# Altium schematic sheet 电源.SchDoc(U_电源)
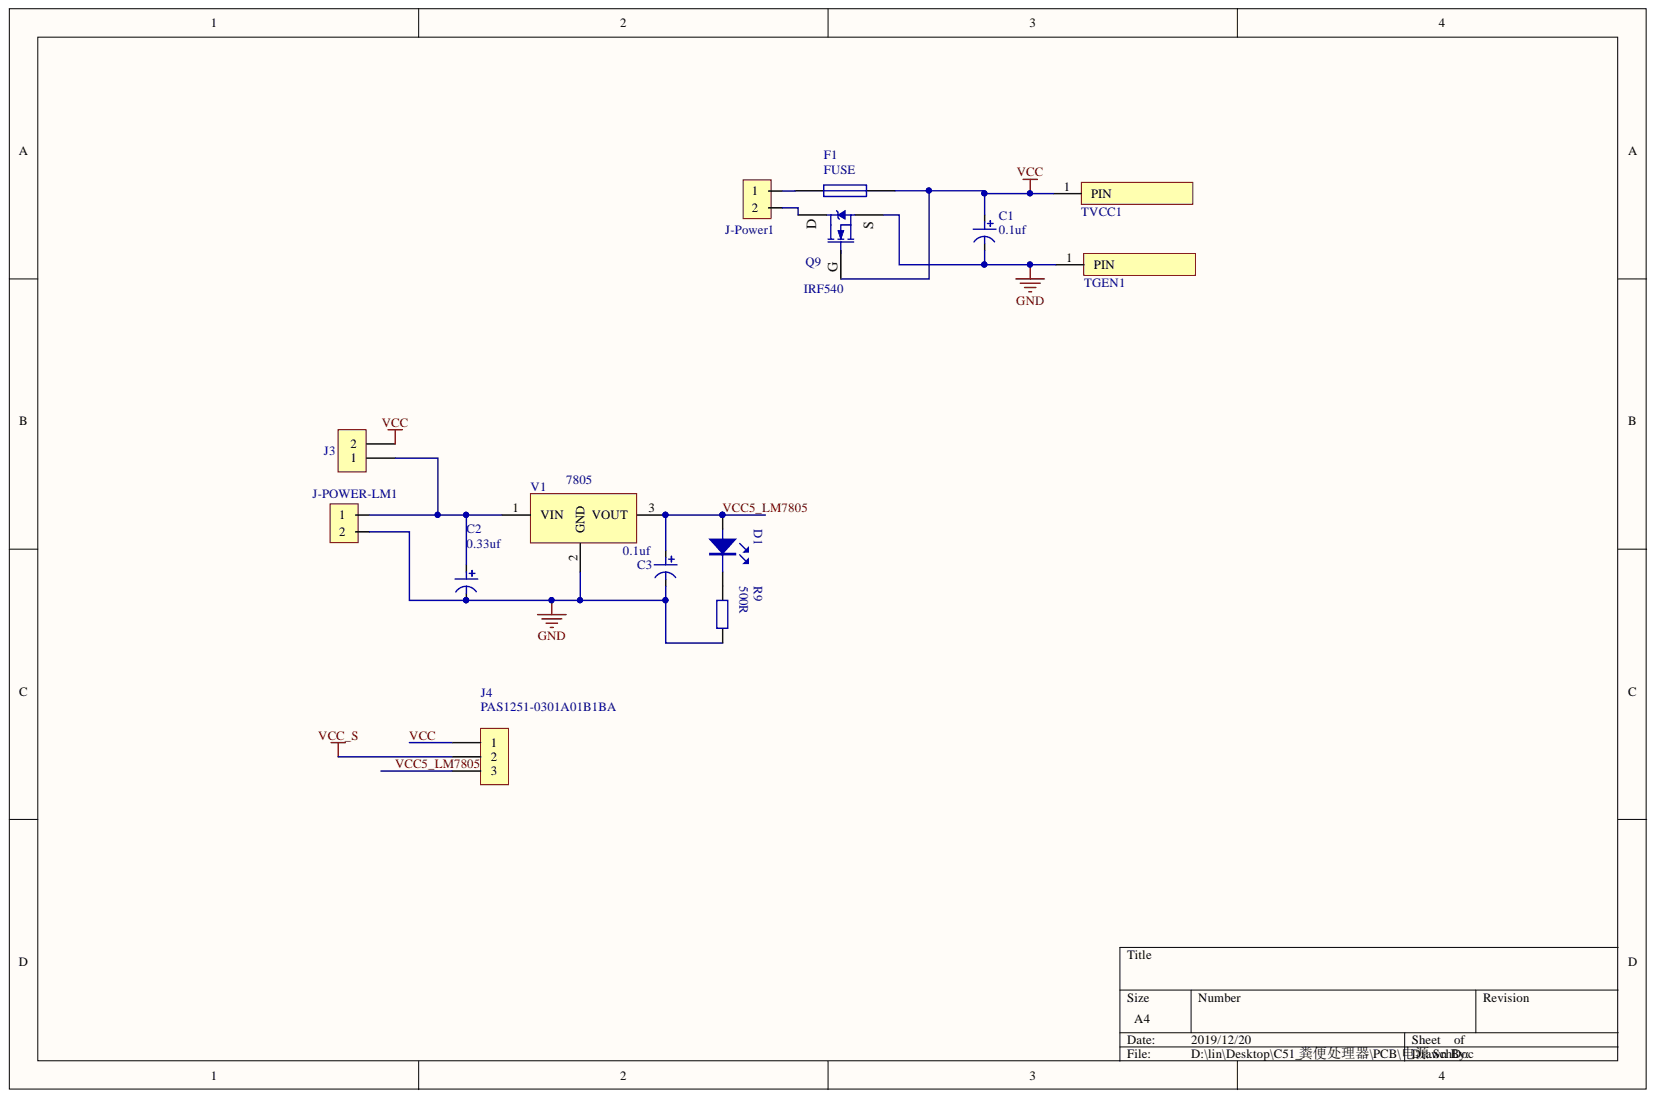
[diagram p0: 电源.SchDoc]

## Parts
C1  0.1uf  [CAP 1.5*4*8]
C2  0.33uf  [CAP 1.5*4*8]
C3  0.1uf  [CAP 1.5*4*8]
D1  333-2SYGD/S530-E2  [LED2-D5R9X8R7]  (value not drawn)
F1  FUSE  [FUSE-24R1X3RX29R7-TH]
J3  PAS1251-0201A01B1BA  [CON2P-2R54-TH]  (value not drawn)
J4  PAS1251-0301A01B1BA  [CON3P-2R54-TH]
J-Power1  KF301-5.08-2p  [KF301-5.0-2P]  (value not drawn)
J-POWER-LM1  KF301-5.08-2p  [KF301-5.0-2P]  (value not drawn)
Q9  IRF540  [TO220A]
R9  500R  [RY0R25W]
TGEN1  T？  [铺铜条]
TVCC1  T？  [铺铜条]
V1  7805  [TO220A]

## Nets
GND: C1.2 C2.2 C3.2 J-POWER-LM1.2 Q9.3 R9.2 TGEN1.1 V1.2
VCC_S: J4.2
VCC: C1.1 F1.2 J3.2 J4.1 Q9.1 TVCC1.1
VCC5_LM7805: C3.1 D1.1 J4.3 V1.3
NetC2_1: C2.1 J3.1 J-POWER-LM1.1 V1.1
NetD1_2: D1.2 R9.1
NetF1_1: F1.1 J-Power1.1
NetJ-Power1_2: J-Power1.2 Q9.2

## Library data of the parts
C1-C3 = 102CB-00002OD.01: DIP,电容量681pf,额定电压2000V,偏差±10%,6.5X5mm; Allow Altenative Part: Y; Approved: YES; Categories: 电容; COMPONENTLINK 1 DESCRIPTION: ShowDatasheet; Database Table Name: CAP_Alum; Modify time: 2015年11月05号; Rated Voltage: 2000V; Remark: Verified by BU1（黄雄青） check list V1.0; serial number: 14; Tolerance: ±10%; Value: 681pF; Applications: Automotive; Category: 陶瓷电容; Component Kind: Standard; COMPONENTLINK 1 URL: http://pdf-file.ic37.com/pdf6/TDK/CK45-B3DD222KYNA_datasheet_1118532/182787/CK45-B_datasheet.pdf; Library Name: IA-04.DbLib; Max Thickness: 5; MOQ: 1000; Mounting Type: ThroughHole; Operating Temperature: -25°C~105°C; PACKAGE: Bulk; Part Number: 102CB-00002OD.01; Pricing 1: 0.7242; Size: 6.5; Supplier 1: TDK; Supplier Part Number 1: CK45-B3DD681KYLR
D1 = 102DL-00010CH.01: DIP,封装/间距直径5/2.54mm,额定电压2V,亮度普亮,绿色; Allow Altenative Part: Y; Approved: YES; Categories: 二极管; COMPONENTLINK 1 DESCRIPTION: GetDatasheet; Database Table Name: Diode; Modify time: 2015年11月11号; Rated Voltage: 2V; Remark: Verified by CRD（张宗良） check list V1.0; serial number: 4; Category: 发光二极管; Component Kind: Standard; COMPONENTLINK 1 URL: http://www.everlight.com/file/ProductFile/201407052217358844.pdf; Library Name: IA-04.DbLib; MOQ: 4000; Mounting Type: ThroughHole; Other: 绿色; PACKAGE: Bulk; Part Number: 102DL-00010CH.01; Pricing 1: .3619; Supplier 1: 台湾亿光; Supplier Part Number 1: 333-2SYGD/S530-E2; Value: 333-2SYGD/S530-E2
F1 = 102FP-00037BI.01: DIP,24.1x29.7x3.0mm,额定电压30V,额定电流9A,触发电流18A,中速断; Allow Altenative Part: Y; Approved: YES; Categories: 保险丝; COMPONENTLINK 1 DESCRIPTION: GetDatasheet; Modify time: 2015年07月10号; Rated Current: 9A; Rated Voltage: 30V; Remark: Verified by BU1（黄雄青） check list V1.0; serial number: 2; Value: R30-900; Category: 自恢复保险丝; Component Kind: Standard; COMPONENTLINK 1 URL: http://www.littelfuse.name/te_product_d_c914/2013-10-18/7630.chtml; Database Table Name: Fuse; Library Name: IA-04.DbLib; MOQ: 500; Mounting Type: ThroughHole; PACKAGE: Bulk; Part Number: 102FP-00037BI.01; Pricing 1: .4; Supplier 1: SEA&LAND; Supplier Part Number 1: R30-900
J3 = 102NL-00005DJ.01: DIP,封装脚距2.54mm,180度,1x2PIN,上针/下针6.0/3.0mm,黑色; Allow Altenative Part: Y; Approved: YES; Categories: 连接器; COMPONENTLINK 1 DESCRIPTION: GetDatasheet; Database Table Name: Connector; Modify time: 2016年08月22号; Remark: Verified by BU2（叶俊雄） check list V1.0; serial number: 286; Category: 单排针; Component Kind: Standard; COMPONENTLINK 1 URL: http://www.hkjb888.com/product.asp?action=detailed&id=1099&page=3&pid=245; Library Name: IA-04.DbLib; MOQ: 500; Mounting Type: ThroughHole; PACKAGE: Bulk; Part Number: 102NL-00005DJ.01; Pricing 1: .03; Supplier 1: 骏佳; Supplier Part Number 1: PAS1251-0201A01B1BA; Value: PAS1251-0201A01B1BA
J4 = 102NL-00001DJ.01: DIP,封装脚距2.54mm,180度,1x3PIN,黑色; Allow Altenative Part: Y; Approved: YES; Categories: 连接器; COMPONENTLINK 1 DESCRIPTION: GetDatasheet; Database Table Name: Connector; Modify time: 2016年1月11号; Remark: Verified by CRD（赖程奇） check list V1.0; serial number: 168; Category: 单排针; Component Kind: Standard; COMPONENTLINK 1 URL: http://www.hkjb888.com/product.asp?page=7; Library Name: IA-04.DbLib; MOQ: 100; Mounting Type: ThroughHole; PACKAGE: Bulk; Part Number: 102NL-00001DJ.01; Pricing 1: 2.05; Supplier 1: 骏佳; Supplier Part Number 1: PAS1251-0301A01B1BA; Value: PAS1251-0301A01B1BA
J-Power1 J-POWER-LM1 = KF301: Component Kind: Standard; Library Name: KF接口.SchLib
Q9 = IRF840: N沟场效应管; 版权归属: 源创客; BOM名称: N沟场效应管; Component Kind: Standard; Library Name: 6.1   - 场效应管-可控硅.SchLib; 学习资源: www.ooocke.com
R9 = 102RO-00087BS.01: DIP,阻值500R,精度±0.1%,4.75x1.65mm,额定功率1/4W; Allow Altenative Part: Y; Approved: YES; Categories: 电阻; COMPONENTLINK 1 DESCRIPTION: OpenDatasheet; Database Table Name: RES_ESP; Modify time: 2015年11月30号; Rated Power: 1/4W; Remark: Verified by BU3（吴明徽）check list V1.0; serial number: 14; Tolerance: ±0.1%; Category: 功率电阻; Component Kind: Standard; COMPONENTLINK 1 URL: http://www.vishay.com/docs/31018/cmfind.pdf; Library Name: IA-04.DbLib; MOQ: 1000; Mounting Type: ThroughHole; PACKAGE: Bulk; Part Number: 102RO-00087BS.01; Pricing 1: 1.29322; Size: 1.65x4.75mm; Supplier 1: Vishay; Supplier Part Number 1: CMF50500R00BKRE; Value: 500R
TGEN1 TVCC1 = 铺铜条: 2mm*20的铜条用于焊接; Component Kind: Standard; Library Name: KF接口.SchLib
V1 = 78xx: 线性稳压芯片; 版权归属: 源创客; BOM名称: 线性稳压芯片; Component Kind: Standard; Library Name: 1.3   - 电源类芯片.SchLib; 学习资源: www.ooocke.com
